# Altium schematic sheet Motor Driver-2.SchDoc
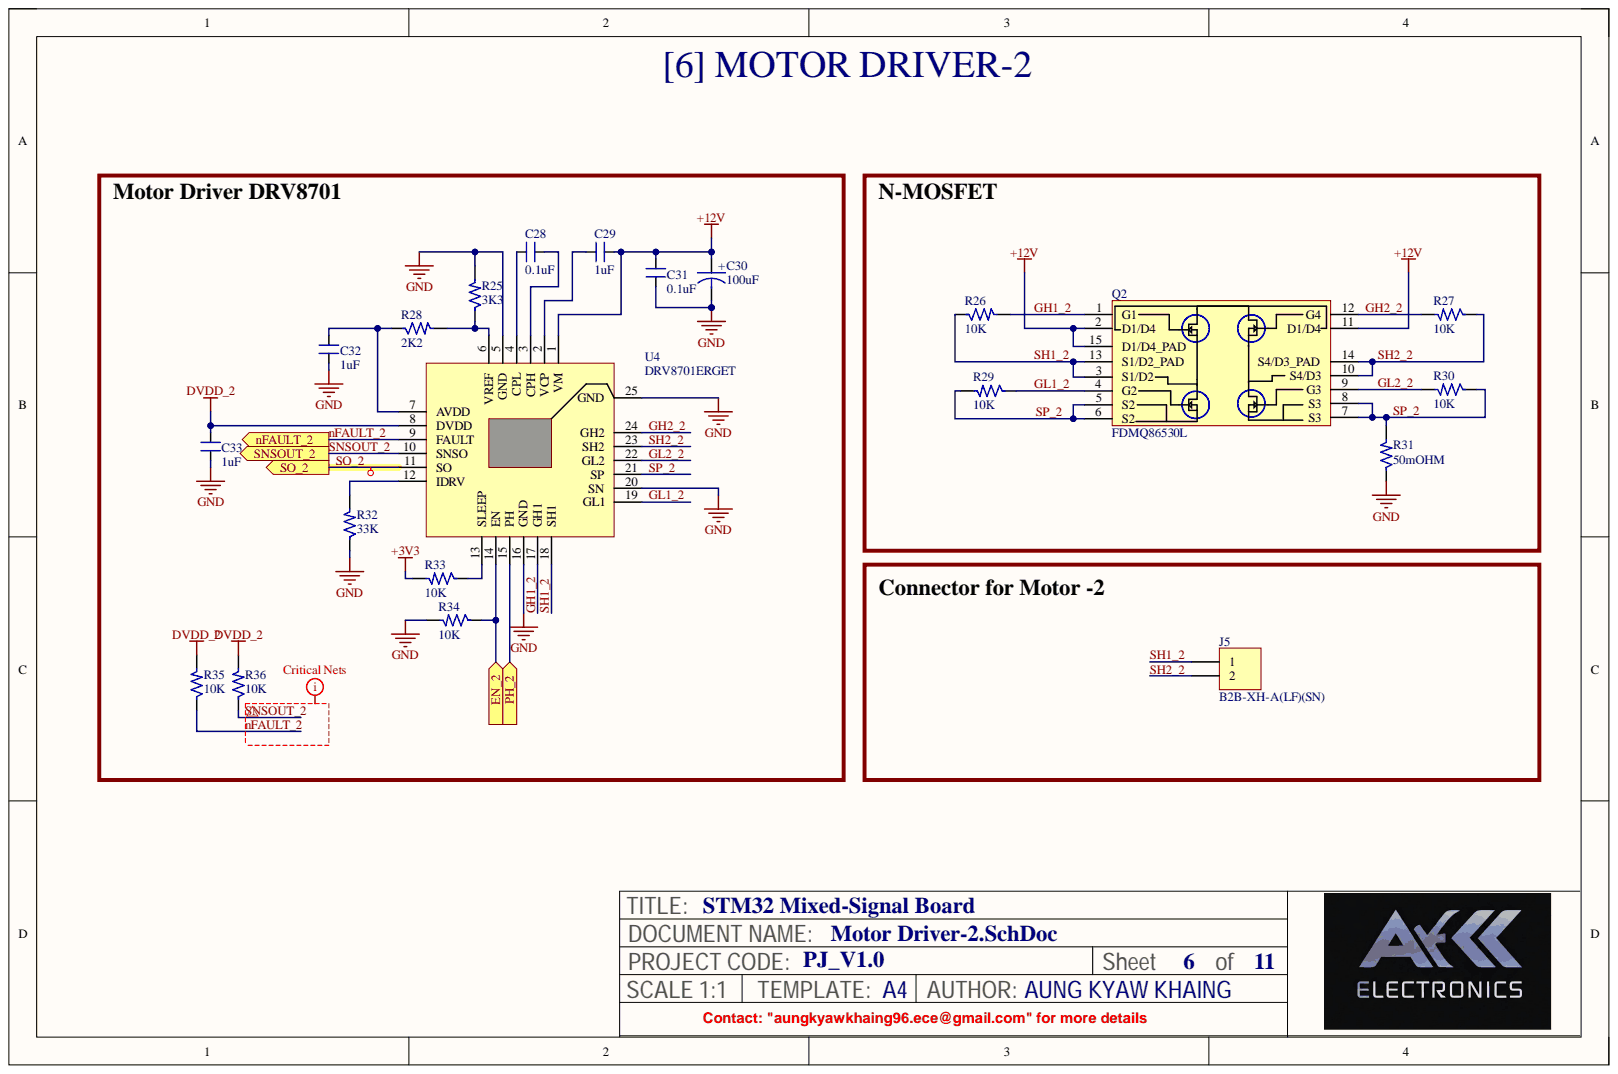
[diagram p0: Motor Driver-2.SchDoc]

## Parts
C28  0.1uF  [CAP_0805]
C29  1uF  [CAP_0805]
C30  100uF  [ACAP_P236_D305_H303_RAD]
C31  0.1uF  [CAP_0805]
C32  1uF  [CAP_0805]
C33  1uF  [CAP_0805]
J5  B2B-XH-A(LF)(SN)  [CON2_100_B2B-XH-A_TH_ST]
Q2  FDMQ86530L  [DFN5045-12_33_212_27X15_SMD_1]
R25  3K3  [RES_0603]
R26  10K  [RES_0603]
R27  10K  [RES_0603]
R28  2K2  [RES_0603]
R29  10K  [RES_0603]
R30  10K  [RES_0603]
R31  50mOHM  [RES_2512]
R32  33K  [RES_0805]
R33  10K  [RES_0603]
R34  10K  [RES_0603]
R35  10K  [RES_0603]
R36  10K  [RES_0603]
U4  DRV8701ERGET  [VFQFN24_19.6_196_12X32_SMD_1]

## Ports
EN_2, nFAULT_2, PH_2, SNSOUT_2, SO_2

## Nets
+3V3: R33.2
+12V: C29.1 C30.1 C31.1 Q2.2(D1/D4) Q2.11(D1/D4) Q2.15(D1/D4_PAD) U4.1(VM)
DVDD_2: C33.1 R35.2 R36.2 U4.8(DVDD)
GND: C30.2 C31.2 C32.2 C33.2 R25.2 R31.1 R32.1 R34.2 U4.5(GND) U4.16(GND) U4.20(SN) U4.25(GND)
EN_2: R34.1 U4.14(EN) ; port EN_2
GH1_2: Q2.1(G1) R26.2 U4.17(GH1)
GH2_2: Q2.12(G4) R27.2 U4.24(GH2)
GL1_2: Q2.4(G2) R29.1 U4.19(GL1)
GL2_2: Q2.9(G3) R30.2 U4.22(GL2)
nFAULT_2: R35.1 U4.9(FAULT) ; port nFAULT_2
PH_2: U4.15(PH) ; port PH_2
SH1_2: J5.1 Q2.3(S1/D2) Q2.13(S1/D2_PAD) R26.1 U4.18(SH1)
SH2_2: J5.2 Q2.10(S4/D3) Q2.14(S4/D3_PAD) R27.1 U4.23(SH2)
SNSOUT_2: R36.1 U4.10(SNSO) ; port SNSOUT_2
SO_2: U4.11(SO) ; port SO_2
SP_2: Q2.5(S2) Q2.6(S2) Q2.7(S3) Q2.8(S3) R29.2 R30.1 R31.2 U4.21(SP)
NetC28_1: C28.1 U4.3(CPH)
NetC28_2: C28.2 U4.4(CPL)
NetC29_2: C29.2 U4.2(VCP)
NetC32_1: C32.1 R28.1 U4.7(AVDD)
NetR25_1: R25.1 R28.2 U4.6(VREF)
NetR32_2: R32.2 U4.12(IDRV)
NetR33_1: R33.1 U4.13(SLEEP)

## Library data of the parts
C28 C31 = CAP_0.1uF-50V-10%-0805: 0.1µF ±10% 50V Ceramic Capacitor X7R 0805 (2012 Metric); Alternate Part Number-1: NA; Manufacturer: Samsung; Manufacturer Part Number: CL21B104KBCNNNC; Case/Package: 0805; Component Kind: Standard; Library Name: ESTEEMPCBLIB.IntLib; Supplier Part Number-1: 0805B104K500CT; Supplier-1: SIRICOM
C29 C32 C33 = CAP_1uF-50V-5%-0805: 1µF ±5% 50V Ceramic Capacitor X7R 0805 (2012 Metric); Alternate Part Number-1: 0805F105M500NT; Manufacturer: Samsung; Manufacturer Part Number: CC0805JKX7R9BB105; Case/Package: 0805; Component Kind: Standard; Library Name: ESTEEMPCBLIB.IntLib; Supplier Part Number-1: CL21B105KBFNNNE; Supplier-1: SIRICOM
C30 = CAP-P_100uF-25V-20%-RAD: 100µF 25V Aluminum Electrolytic Capacitors Radial, Can - SMD 1000 Hrs @ 105°C; Alternate Part Number-1: NA; Manufacturer: Nichicon; Manufacturer Part Number: UWT1E101MCL1GS; Case/Package: Radial-SMD; Component Kind: Standard; Library Name: ESTEEMPCBLIB.IntLib; Supplier Part Number-1: UWT1E101MCL1GS; Supplier-1: SP ROAD
J5 = CON-PLG_2X1-100-ST-TH: 2 Pins, 1 Row 2.54mm Pitch, Straight Connector, male; Alternate Part Number-1: NA; Crimp Part Number: ASXHSXH22K51; Manufacturer: JST; Mating Part Number: XHP-2; Case/Package: TH-CON; Component Kind: Standard; Library Name: ESTEEMPCBLIB.IntLib; Manufacturer Part Number: B2B-XH-A(LF)(SN); Supplier Part Number-1: B2B-XH-A(LF)(SN); Supplier-1: SP ROAD
Q2 = MOSFET-N_60V-8A-V-DFN5045-12: Mosfet Array 4 N-Channel 8A (Ta) Surface Mount V-DFN5045-12; Alternate Part Number-1: NA; Manufacturer: ON Semiconductor; Case/Package: DFN5045-12; Component Kind: Standard; Library Name: ESTEEMPCBLIB.IntLib; Manufacturer Part Number: FDMQ86530L; Supplier Part Number-1: FDMQ86530L; Supplier-1: Arrow
R25 = RES_3.3K-0.1W-1%-0603: 3.3 kOhms ±1% 0.1W, 1/10W Chip Resistor 0603 (1608 Metric) Automotive AEC-Q200 Thick Film; Alternate Part Number-1: 0603WAF3301T5E; Manufacturer: Wilson; Manufacturer Part Number: WR06X3301FTL; Case/Package: 0603; Component Kind: Standard; Library Name: ESTEEMPCBLIB.IntLib; Supplier Part Number-1: WR06X3301FTL; Supplier-1: SIRICOM
R26 R27 R29 R30 R33-R36 = RES_10K-0.1W-1%-0603: 10 kOhms ±1% 0.1W, 1/10W Chip Resistor 0603 (1608 Metric) Automotive AEC-Q200 Thick Film; Alternate Part Number-1: 0603WAJ0103T5E; Manufacturer: Walsin; Manufacturer Part Number: WR06X1002FTL; Case/Package: 0603; Component Kind: Standard; Library Name: ESTEEMPCBLIB.IntLib; Supplier Part Number-1: WR06X1002FTL; Supplier-1: SIRICOM
R28 = RES_2K2-0.1W-5%-0603: 2.2 kOhms ±5% 0.1W, 1/10W Chip Resistor 0603 (1608 Metric) Automotive AEC-Q200 Thick Film; Alternate Part Number-1: WR06X2201FTL; Manufacturer: Stackpole Electronics; Manufacturer Part Number: ERJ-3GEYJ222V; Case/Package: 0603; Component Kind: Standard; Library Name: ESTEEMPCBLIB.IntLib; Supplier Part Number-1: WR06X2201FTL; Supplier-1: SIRICOM
R31 = RES_50mOhm-2W-1%-2512: 50 mOhms ±1% 2W Chip Resistor 2512 (6432 Metric) Automotive AEC-Q200, Current Sense, Moisture Resistant Metal Element; Alternate Part Number-1: PMR100HZPFU5L00; Manufacturer: Yageo; Manufacturer Part Number: PA2512FKF7W0R05E; Case/Package: 2512; Component Kind: Standard; Library Name: ESTEEMPCBLIB.IntLib; Supplier Part Number-1: LR2512-22R060F1; Supplier-1: SIRICOM
R32 = RES_33K-0.125W-1%-0805: Thick Film Resistors - SMD 33KOhms 1/8W 150V33 kOhms ±1% 0.125W, 1/8W Chip Resistor 0805 (2012 Metric) Thick Film; Alternate Part Number-1: NA; Manufacturer: Vishay BCcomponents; Manufacturer Part Number: CRG0805F33K; Case/Package: 0805; Component Kind: Standard; Library Name: ESTEEMPCBLIB.IntLib; Supplier Part Number-1: WR08X3302FTL; Supplier-1: SIRICOM
U4 = IC_DRV8701ERGET: Motor Driver Power MOSFET Parallel 24-VQFN (4x4); Alternate Part Number-1: NA; Manufacturer: Texas Instruments; Case/Package: VFQFN24; Component Kind: Standard; Library Name: ESTEEMPCBLIB.IntLib; Manufacturer Part Number: DRV8701ERGET; Supplier Part Number-1: DRV8701ERGET; Supplier-1: ARROW
